# Altium schematic sheet HX-DK-商.SchDoc
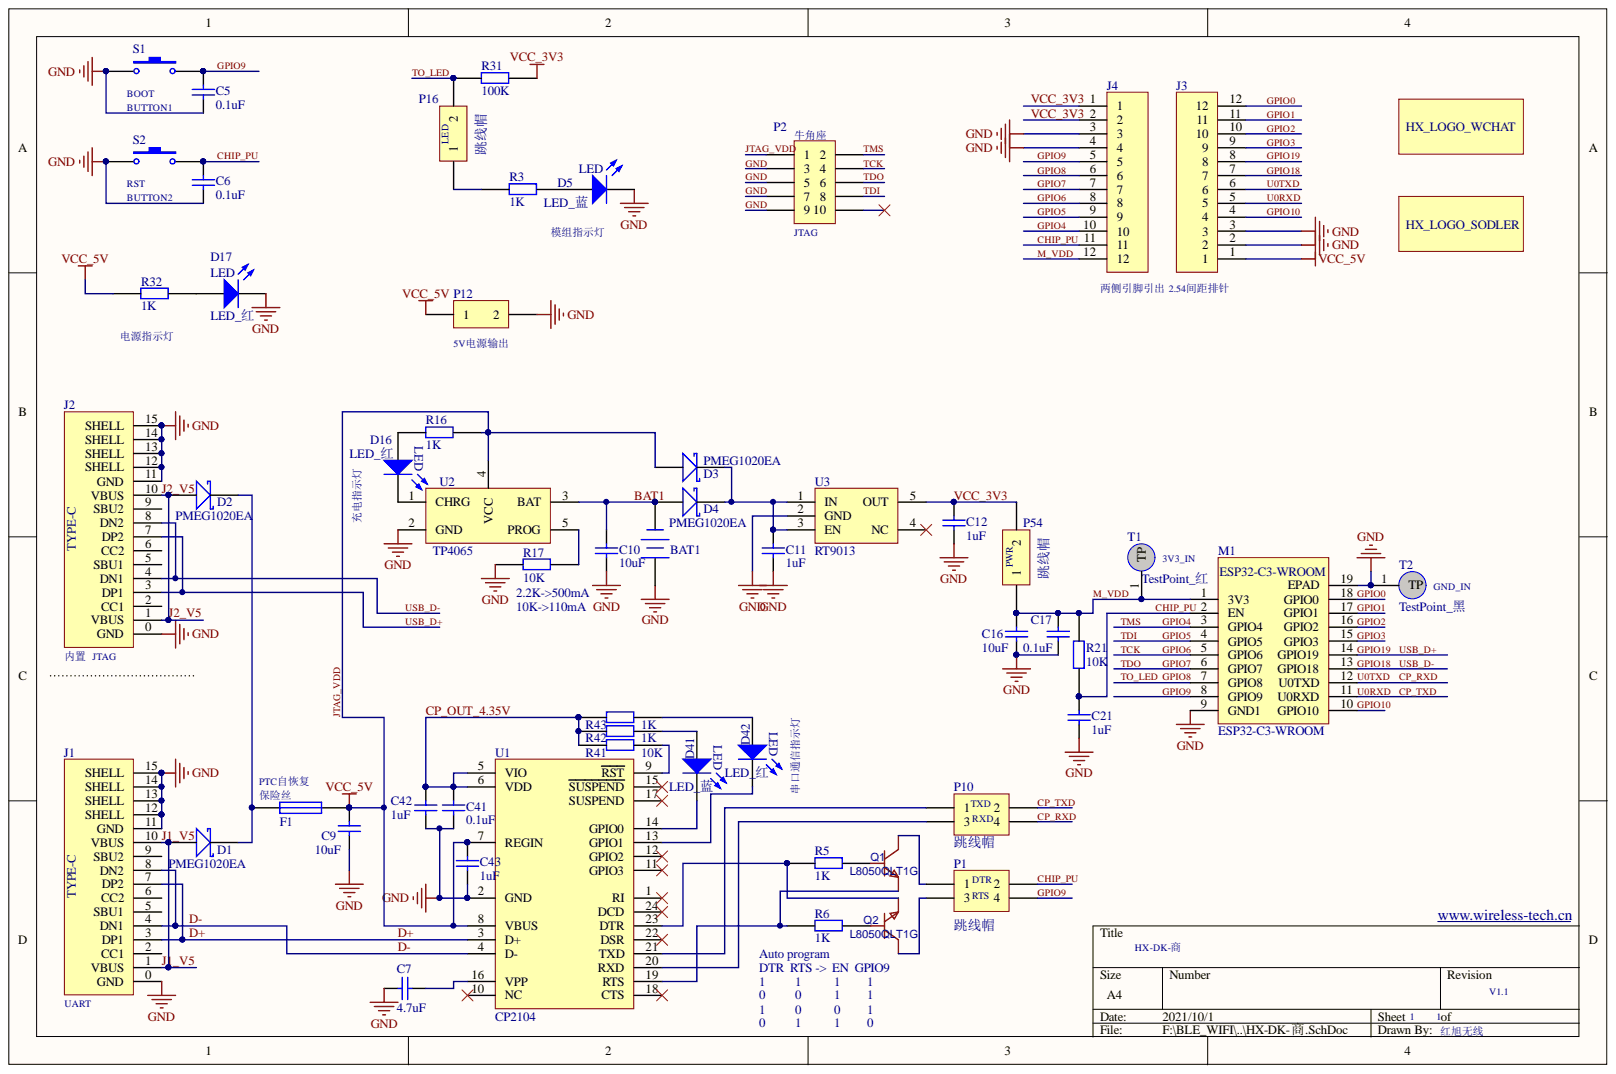
[diagram p0: HX-DK-商.SchDoc]

## Parts
BAT1  1.25T-2PWT_连接器  [CON_DZ_2_1.25MM]  (value not drawn)
C5  0.1uF  [RC0603]
C6  0.1uF  [RC0603]
C7  4.7uF  [RC1206]
C9  10uF  [RC1206]
C10  10uF  [RC1206]
C11  1uF  [RC0603]
C12  1uF  [RC0603]
C16  10uF  [RC1206]
C17  0.1uF  [RC0603]
C21  1uF  [RC0603]
C41  0.1uF  [RC0603]
C42  1uF  [RC0603]
C43  1uF  [RC0603]
D1  PMEG1020EA  [PMEG1020EA]
D2  PMEG1020EA  [PMEG1020EA]
D3  PMEG1020EA  [PMEG1020EA]
D4  PMEG1020EA  [PMEG1020EA]
D5  LED_蓝  [LED0603]
D16  LED_红  [LED0603]
D17  LED_红  [LED0603]
D41  LED_蓝  [LED0603]
D42  LED_红  [LED0603]
F1  0R  [RC0603]  (value not drawn)
J1  TYPE-C16PIN  [TYPE-C_16PIN_shouhan]  (value not drawn)
J2  TYPE-C16PIN  [TYPE-C_16PIN_shouhan]  (value not drawn)
J3  CON12_2.54  [CON1X12_2.54]  (value not drawn)
J4  CON12_2.54  [CON1X12_2.54]  (value not drawn)
LOGO  LOGO  [HX_LOGO_TOPSolder]
LOGO2  HX_LOGO_W  [HX_LOGO_WCHAT_M]
M1  ESP32-C3-WROOM  [ESP32-C3-WROOM2]
P1  CON2X2_2.54  [CON2X2_2.54]  (value not drawn)
P2  牛角座-2X5  [CON2X5_2.54_NIU]  (value not drawn)
P10  CON2X2_2.54  [CON2X2_2.54]  (value not drawn)
P12  CON2_2.54  [CON1X2_2.54]  (value not drawn)
P16  CON2_2.54  [CON1X2_2.54]  (value not drawn)
P54  CON2X2.54  [CON1X2_2.54]  (value not drawn)
Q1  L8050QLT1G  [SOT-23-3_L2.9-W1.3-P1.90-LS2.4-BR]
Q2  L8050QLT1G  [SOT-23-3_L2.9-W1.3-P1.90-LS2.4-BR]
R3  1K  [RC0603]
R5  1K  [RC0603]
R6  1K  [RC0603]
R16  1K  [RC0603]
R17  10K  [RC0603]
R21  10K  [RC0603]
R31  100K  [RC0603]
R32  1K  [RC0603]
R41  10K  [RC0603]
R42  1K  [RC0603]
R43  1K  [RC0603]
S1  TS342A2P  [BUTTON3X4X2]  (value not drawn)
S2  TS342A2P  [BUTTON3X4X2]  (value not drawn)
T1  TestPoint_红  [CON1X1_2.54_红]
T2  TestPoint_黑  [CON1X1_2.54_黑]
U1  CP2104  [CP2104_F03_GM]
U2  TP4065  [SOT23-5]
U3  RT9013  [SOT23-5]

## Nets
BAT1: BAT1.2 C10.2 D4.1 U2.3
CHIP_PU: C6.1 C21.2 J4.11 M1.2 P1.2 R21.1 S2.2
CP_OUT_4.35V: C41.2 C42.2 R41.2 R42.1 R43.1 U1.5 U1.6
CP_RXD: J3.6 M1.12 P10.4
CP_TXD: J3.5 M1.11 P10.2
D+: J1.3 J1.7 U1.3
D-: J1.4 J1.8 U1.4
GND: BAT1.1 BAT1.3 BAT1.4 C5.2 C6.2 C7.2 C9.1 C10.1 C11.1 C12.2 C16.1 C17.1 C21.1 C41.1 C42.1 C43.1 D5.2 D17.2 J1.0 J1.11 J1.12 J1.13 J1.14 J1.15 J2.0 J2.11 J2.12 J2.13 J2.14 J2.15 J3.2 J3.3 J4.3 J4.4 M1.9 M1.19 P2.3 P2.5 P2.7 P2.9 P12.2 R17.1 S1.1 S2.1 T2.1 U1.2 U2.2 U3.2
GPIO0: J3.12 M1.18
GPIO1: J3.11 M1.17
GPIO2: J3.10 M1.16
GPIO3: J3.9 M1.15
GPIO4: J4.10 M1.3 P2.2
GPIO5: J4.9 M1.4 P2.8
GPIO6: J4.8 M1.5 P2.4
GPIO7: J4.7 M1.6 P2.6
GPIO8: J4.6 M1.7 P16.2 R31.2
GPIO9: C5.1 J4.5 M1.8 P1.4 S1.2
GPIO10: J3.4 M1.10
GPIO18: J2.4 J2.8 J3.7 M1.13
GPIO19: J2.3 J2.7 J3.8 M1.14
J1_V5: D1.1 J1.1 J1.10
J2_V5: D2.1 J2.1 J2.10
JTAG_VDD: C9.2 C43.2 D3.1 F1.2 J3.1 P2.1 P12.1 R16.2 R32.1 U1.7 U1.8 U2.4
M_VDD: C16.2 C17.2 J4.12 M1.1 P54.1 R21.2 T1.1
VCC_3V3: C12.1 J4.1 J4.2 P54.2 R31.1 U3.5
NetC7_1: C7.1 U1.16
NetC11_2: C11.2 D3.2 D4.2 U3.1 U3.3
NetD1_2: D1.2 D2.2 F1.1
NetD5_1: D5.1 R3.2
NetD16_1: D16.1 R16.1
NetD16_2: D16.2 U2.1
NetD17_1: D17.1 R32.2
NetD41_1: D41.1 R42.2
NetD41_2: D41.2 U1.14
NetD42_1: D42.1 R43.2
NetD42_2: D42.2 U1.13
NetP1_1: P1.1 Q1.3
NetP1_3: P1.3 Q2.3
NetP10_1: P10.1 U1.21
NetP10_3: P10.3 U1.20
NetP16_1: P16.1 R3.1
NetQ1_1: Q1.1 R5.2
NetQ1_2: Q1.2 R6.1 U1.19
NetQ2_1: Q2.1 R6.2
NetQ2_2: Q2.2 R5.1 U1.23
NetR17_2: R17.2 U2.5
NetR41_1: R41.1 U1.9

Not connected: J1 2 5 6 9; J2 2 5 6 9; P2 10; U1 1 10 11 12 15 17 18 22 24; U3 4

## Library data of the parts
BAT1 = BAT: Component Kind: Standard; Library Name: Schlib.SchLib; value: 1.25T-2PWT_连接器
C5 C6 C17 C41-C43 = CAP: Capacitor; Component Kind: Standard; Library Name: Schlib.SchLib
  C5 C6 C17 C41: value: 0.1uF
  C42 C43: value: 1uF
C7 C9-C12 C16 C21 = CAP: Component Kind: Standard; Library Name: YD_Schlib.SchLib
  C7: value: 4.7uF
  C9 C10 C16: value: 10uF
  C11 C12 C21: value: 1uF
D5 D16 D17 D41 D42 = LED: Component Kind: Standard; Library Name: YD_Schlib.SchLib
  D5 D41: value: LED_蓝
  D16 D17 D42: value: LED_红
F1 = FUSE: Component Kind: Standard; Library Name: Schlib.SchLib; value: 0R
J1 J2 = TYPE-C_16P_SHOUHAN: Component Kind: Standard; Library Name: Schlib.SchLib; value: TYPE-C16PIN
J3 J4 = CON1X12_2.54: Component Kind: Standard; value: CON12_2.54; Library Name: YD_Connect.SchLib
LOGO = HX_LOGO_TOPSODLER: Component Kind: Standard; Library Name: Schlib.SchLib; value: LOGO
LOGO2 = HX_LOGO_WCHAT: Component Kind: Standard; Library Name: Schlib.SchLib
P2 = CON2X5_2.54_Niu: Component Kind: Standard; value: 牛角座-2X5; Library Name: YD_Connect.SchLib
P12 P16 P54 = CON1X2_2.54: Component Kind: Standard
  P12 P16: value: CON2_2.54; Library Name: YD_Connect.SchLib
  P54: value: CON2X2.54
Q1 Q2 = L8050QLT1G: BOM_Manufacturer: LRC; BOM_JLCPCB Part Class: Extended Part; BOM_Manufacturer Part: L8050QLT1G; BOM_Supplier: LCSC; BOM_Supplier Part: C49581; Component Kind: Standard; spicePre: Q; spiceSymbolName: L8050QLT1G
R3 R5 R6 R16 R17 R21 R31 R32 R41-R43 = RES: Component Kind: Standard; Library Name: YD_Schlib.SchLib
  R3 R5 R6 R16 R32 R42 R43: value: 1K
  R17 R21 R41: value: 10K
  R31: value: 100K
S1 S2 = BUTTON3X4X2: Component Kind: Standard; Library Name: Schlib.SchLib; value: TS342A2P
T1 T2 = CON1X1_2.54: Component Kind: Standard; Library Name: YD_Connect.SchLib
  T1: value: TestPoint_红
  T2: value: TestPoint_黑
U1 = CP2104_GMR: 芯科USB转TTL芯片; HXID: C47742; Component Kind: Standard; Library Name: Schlib.SchLib; value: CP2104
U3 = RT9013: Chip; Component Kind: Standard; Library Name: Schlib.SchLib; value: RT9013
D1-D4 = PMEG1020EA: Component Kind: Standard; Library Name: Schlib.SchLib; value: PMEG1020EA
M1 = ESP32-C3-WROOM: Component Kind: Standard; Library Name: Module.SchLib; value: ESP32-C3-WROOM
P1 P10 = CON2X2_2.54: Component Kind: Standard; value: CON2X2_2.54; Library Name: YD_Connect.SchLib
U2 = TP4065: Component Kind: Standard; Library Name: Schlib.SchLib; value: TP4065
